# Altium schematic sheet drvinput0.SchDoc(drvinput0)
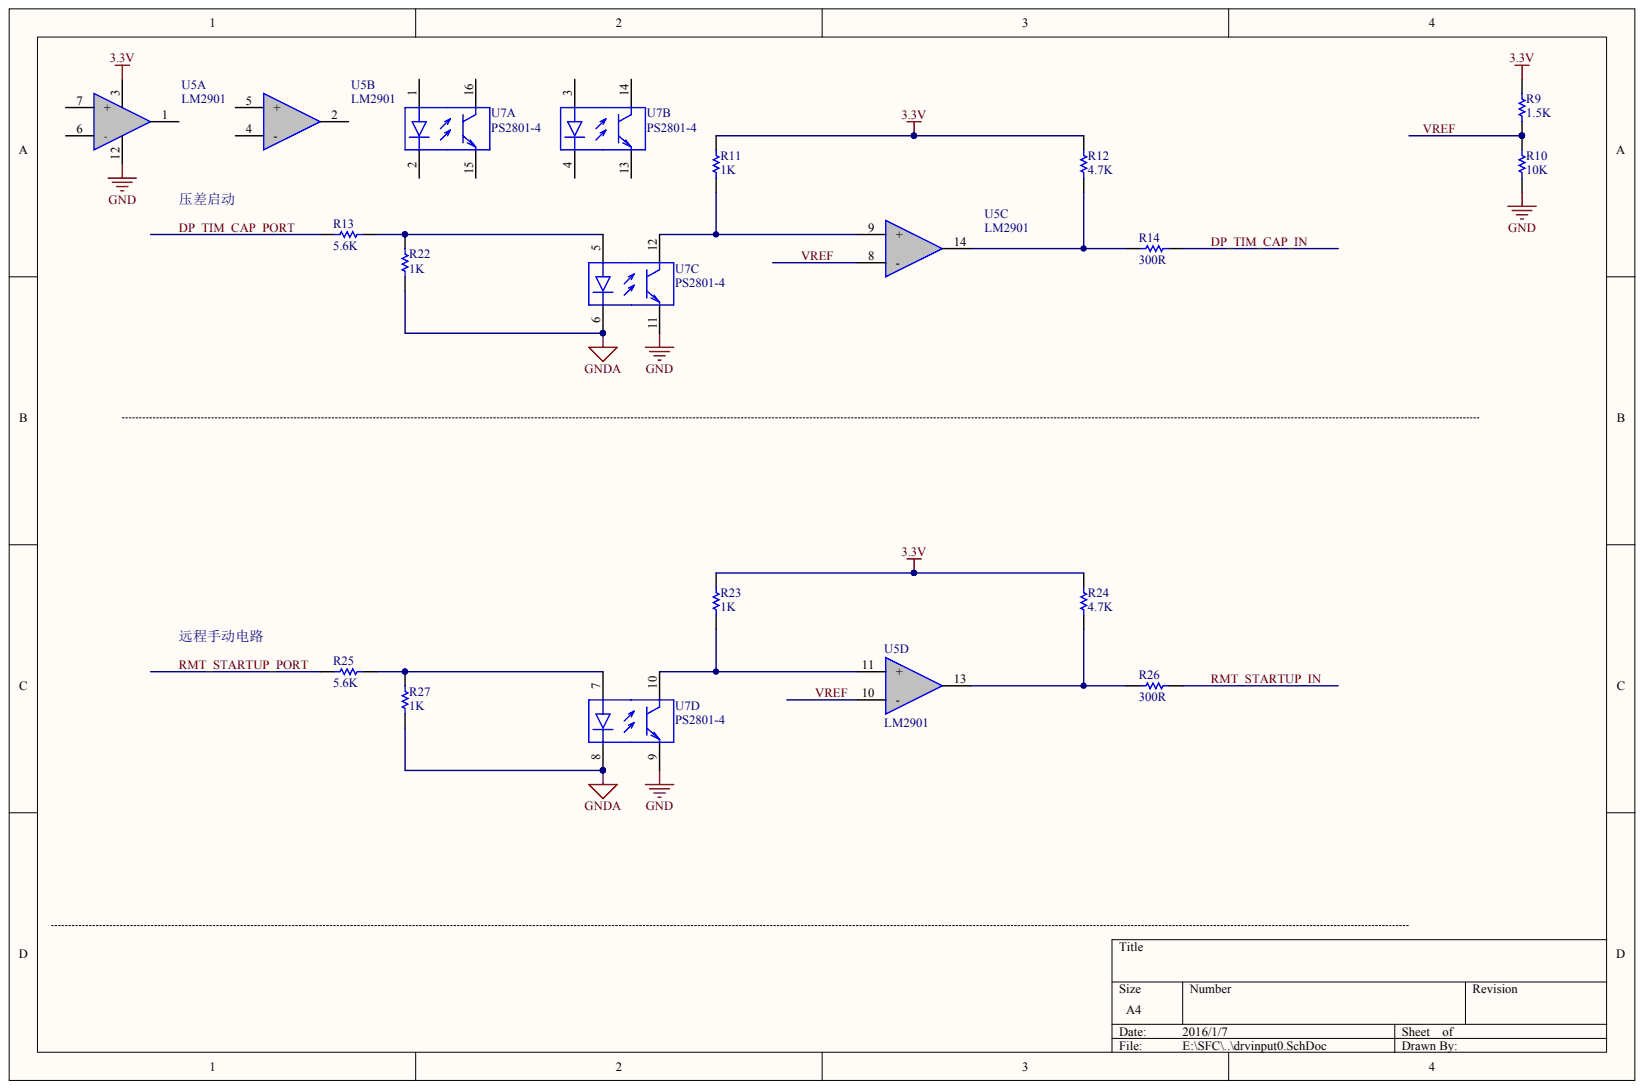
[diagram p0: drvinput0.SchDoc]

## Parts
R9  1.5K
R10  10K
R11  1K
R12  4.7K
R13  5.6K
R14  300R
R22  1K
R23  1K
R24  4.7K
R25  5.6K
R26  300R
R27  1K
U5  LM2901  (parts A,B,C,D)
U7  PS2801-4  (parts A,B,C,D)

## Nets
3.3V: R9.1 R11.1 R12.2 R23.1 R24.2 U5.3
GND: R10.2 U5.12 U7.9 U7.11
GNDA: R22.1 R27.1 U7.6 U7.8
DP_TIM_CAP_IN: R14.1
DP_TIM_CAP_PORT: R13.2
RMT_STARTUP_IN: R26.2
RMT_STARTUP_PORT: R25.2
VREF: R9.2 R10.1 U5.8(-) U5.10(-)
NetR11_2: R11.2 U5.9(+) U7.12
NetR12_1: R12.1 R14.2 U5.14
NetR13_1: R13.1 R22.2 U7.5
NetR23_2: R23.2 U5.11(+) U7.10
NetR24_1: R24.1 R26.1 U5.13
NetR25_1: R25.1 R27.2 U7.7

Not connected: U5 1 2 4(-) 5(+) 6(-) 7(+); U7 1 2 3 4 13 14 15 16

## Library data of the parts
R9 R11-R14 R22-R25 R27 = (no symbol name): Value: 10K; Published: 8-Jun-2000; LatestRevisionDate: 17-Jul-2002; LatestRevisionNote: Re-released for DXP Platform.; PackageReference: AXIAL-0.3; Publisher: Altium Limited
R26 = (no symbol name): Value: 470; Published: 8-Jun-2000; LatestRevisionDate: 17-Jul-2002; LatestRevisionNote: Re-released for DXP Platform.; PackageReference: AXIAL-0.3; Publisher: Altium Limited
R10 U5 U7 = (no symbol name)
  R10: Published: 8-Jun-2000; LatestRevisionDate: 17-Jul-2002; LatestRevisionNote: Re-released for DXP Platform.; PackageReference: AXIAL-0.3; Value: 10K
  U7: Published: 28-Feb-2003; LatestRevisionDate: 13-Apr-2006; LatestRevisionNote: IPC-7351 Footprint Added.; DatasheetVersion: Jan-2002, Rev. 7; PackageVersion: rev7, Jan-2002; PackageReference: 751-07; PackageDescription: 8-Pin Plastic Small Outline Integrated Circuit 1.27 mm Pitch; Code_JEDEC: MS-012-AA; Code_IPC: SOIC127P600-8; ComponentLink1Description: Manufacturer Link; ComponentLink1URL: http://www.onsemi.com/; ComponentLink2Description: Datasheet; ComponentLink2URL: http://www.onsemi.com/pub/Collateral/LM358-D.PDF
  R10 U7: Publisher: Altium Limited
